# Altium schematic sheet FM-STC15.SchDoc
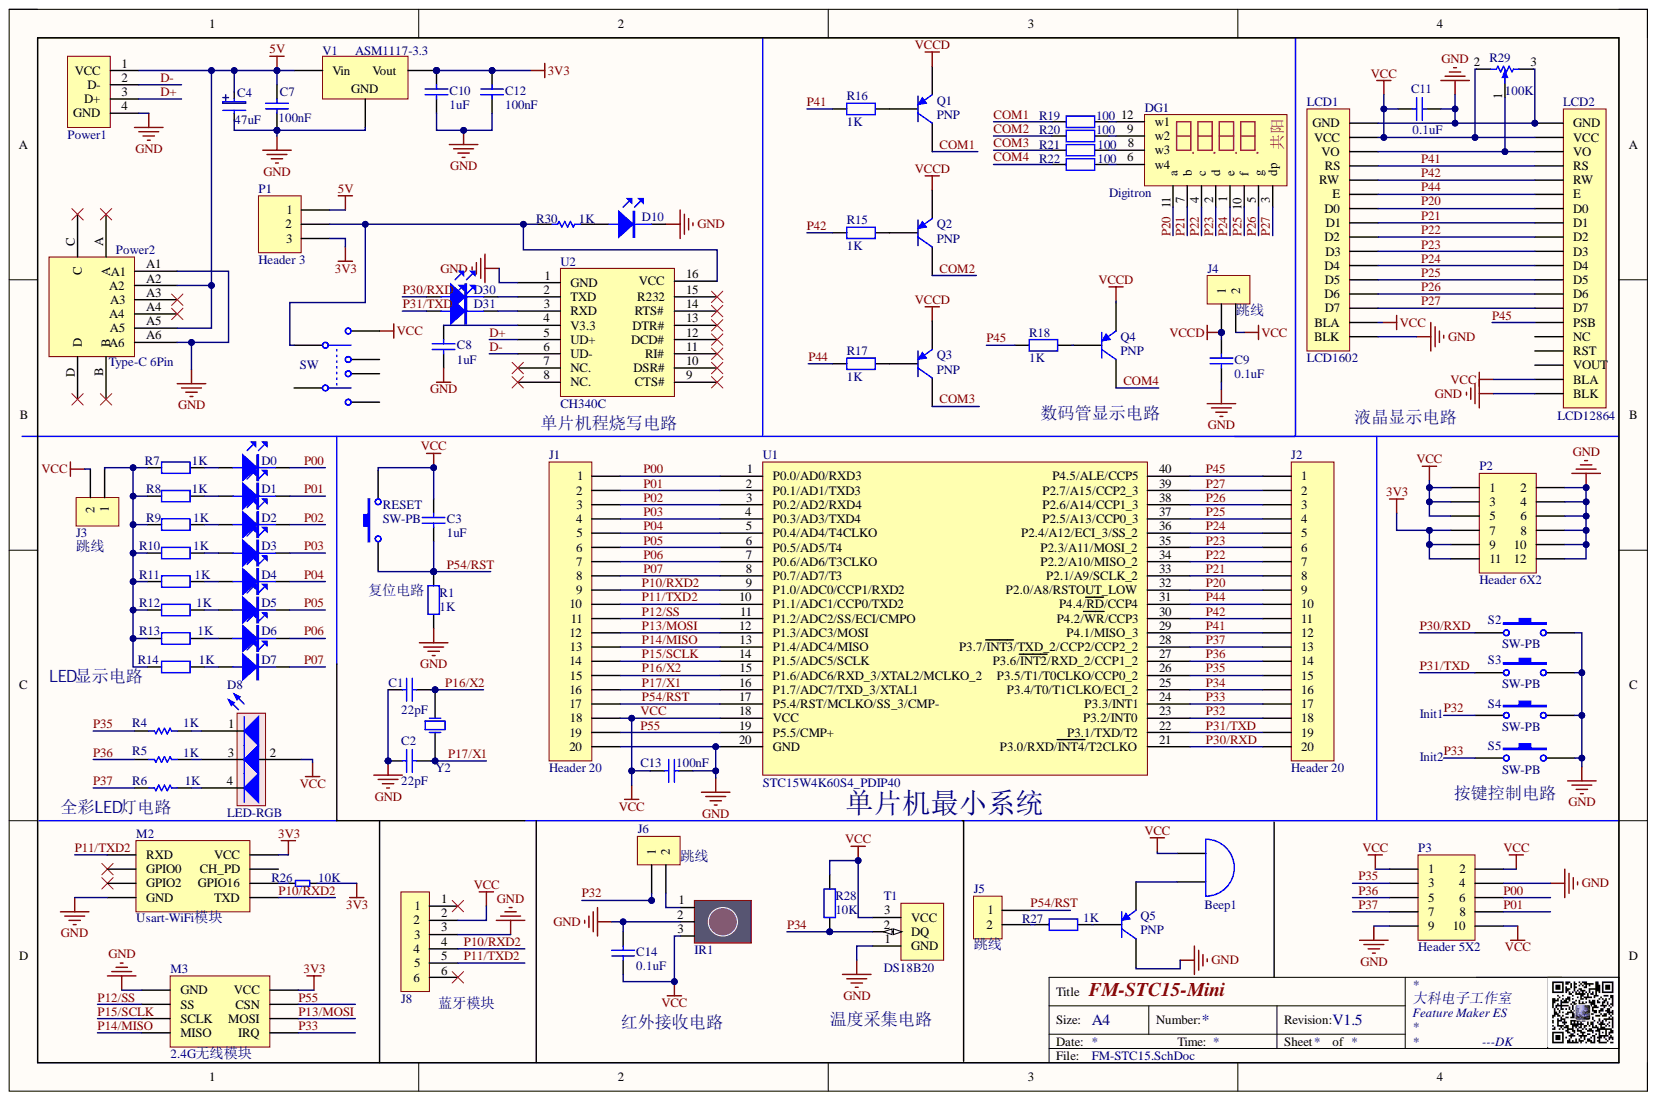
[diagram p0: FM-STC15.SchDoc]

## Parts
Beep1  蜂鸣器  [BELL]  (value not drawn)
C1  value 22pF  [0805-3]  (hidden comment: Cap_SMT)
C2  value 22pF  [0805-3]  (hidden comment: Cap_SMT)
C3  value 1uF  [0805-3]  (hidden comment: Cap_SMT)
C4  47uF  [SMD6.6*6.5]
C7  value 100nF  [0805-3]  (hidden comment: Cap_SMT)
C8  value 1uF  [0805-3]  (hidden comment: Cap)
C9  value 0.1uF  [0805-3]  (hidden comment: Cap_SMT)
C10  value 1uF  [0805-3]  (hidden comment: 100nf)
C11  value 0.1uF  [0805-3]  (hidden comment: Cap_SMT)
C12  value 100nF  [0805-3]  (hidden comment: Cap_SMT)
C13  value 100nF  [0805-3]  (hidden comment: Cap_SMT)
C14  value 0.1uF  [0805-3]  (hidden comment: Cap_SMT)
D0  LED0805  [0805-LED]  (value not drawn)
D1  LED0805  [0805-LED]  (value not drawn)
D2  LED0805  [0805-LED]  (value not drawn)
D3  LED0805  [0805-LED]  (value not drawn)
D4  LED0805  [0805-LED]  (value not drawn)
D5  LED0805  [0805-LED]  (value not drawn)
D6  LED0805  [0805-LED]  (value not drawn)
D7  LED0805  [0805-LED]  (value not drawn)
D8  LED-RGB  [LED-RGB]
D10  LED0805  [0805-LED]  (value not drawn)
D30  LED0805  [0805-3]  (value not drawn)
D31  LED0805  [0805-3]  (value not drawn)
DG1  Digitron  [DYP-4BIT]
IR1  红外接收头  [HDR1X3]  (value not drawn)
J1  Header 20  [HDR1X20-]
J2  Header 20  [HDR1X20-]
J3  跳线  [HDR1X2]
J4  跳线  [HDR1X2]
J5  跳线  [HDR1X2]
J6  跳线  [HDR1X2]
J8  蓝牙模块  [HDR1X6]
LCD1  LCD1602  [HDR1X16]
LCD2  LCD12864  [HDR1X20]
M2  Usart-WiFi模块  [HDR2X4-]
M3  2.4G无线模块  [HDR2X4-]
P1  Header 3  [HDR1X3]
P2  Header 6X2  [HDR2X6-]
P3  Header 5X2  [HDR5X2-]
Power1  USB-B  [USB_1]  (value not drawn)
Power2  Type-C 6Pin  [Type-C_ 6Pin]
Q1  PNP  [SOT-23_M]
Q2  PNP  [SOT-23_M]
Q3  PNP  [SOT-23_M]
Q4  PNP  [SOT-23_M]
Q5  PNP  [SOT-23_M]
R1  value 1K  [0805-3]  (hidden comment: Res_SMT)
R4  1K  [0805-3]
R5  1K  [0805-3]
R6  1K  [0805-3]
R7  value 1K  [0805-3]  (hidden comment: Res_SMT)
R8  value 1K  [0805-3]  (hidden comment: Res_SMT)
R9  value 1K  [0805-3]  (hidden comment: Res_SMT)
R10  value 1K  [0805-3]  (hidden comment: Res_SMT)
R11  value 1K  [0805-3]  (hidden comment: Res_SMT)
R12  value 1K  [0805-3]  (hidden comment: Res_SMT)
R13  value 1K  [0805-3]  (hidden comment: Res_SMT)
R14  value 1K  [0805-3]  (hidden comment: Res_SMT)
R15  value 1K  [0805-3]  (hidden comment: Res_SMT)
R16  value 1K  [0805-3]  (hidden comment: Res_SMT)
R17  value 1K  [0805-3]  (hidden comment: Res_SMT)
R18  value 1K  [0805-3]  (hidden comment: Res_SMT)
R19  value 100  [0805-3]  (hidden comment: Res_SMT)
R20  value 100  [0805-3]  (hidden comment: Res_SMT)
R21  value 100  [0805-3]  (hidden comment: Res_SMT)
R22  value 100  [0805-3]  (hidden comment: Res_SMT)
R26  value 10K  [0805-3]  (hidden comment: R0805)
R27  value 1K  [0805-3]  (hidden comment: Res_SMT)
R28  value 10K  [0805-3]  (hidden comment: Res_SMT)
R29  100K  [Rel]
R30  value 1K  [0805-3]  (hidden comment: Res1)
RESET  SW-PB  [KeyA]
S2  SW-PB  [KEY-SMD]
S3  SW-PB  [KEY-SMD]
S4  SW-PB  [KEY-SMD]
S5  SW-PB  [KEY-SMD]
SW  SW-DPDT  [DPDT-6]  (value not drawn)
T1  DS18B20  [HDR1X3]
U1  STC15W4K60S4_PDIP40  [DIP40]
U2  CH340C  [SO-16]
V1  ASM1117-3.3  [SOT223_N]
Y2  11.0592  [HDR1X3]  (value not drawn)

## Nets
3V3: C10.2 C12.2 M2.2 M3.2 P1.3 P2.7 P2.9 P2.11 R26.1 V1.3
5V: C4.1 C7.1 P1.1 Power1.1 Power2.A2 Power2.A5 V1.1
GND: C1.2 C2.2 C4.2 C7.2 C8.2 C9.1 C10.1 C11.2 C12.1 C13.2 C14.2 D10.2 IR1.2 J1.20 J8.3 LCD1.1 LCD1.16 LCD2.1 LCD2.20 M2.7 M3.1 P2.2 P2.4 P2.6 P2.8 P2.10 P2.12 P3.4 P3.9 Power1.4 Power2.A1 Power2.A6 Q5.3 R1.1 R29.3 S2.2 S3.2 S4.2 S5.2 T1.1 U1.20 U2.1 V1.2
VCCD: C9.2 J4.1 Q1.2 Q2.2 Q3.2 Q4.2
COM1: Q1.3 R19.1
COM2: Q2.3 R20.1
COM3: Q3.3 R21.1
COM4: Q4.3 R22.1
D+: Power1.3 U2.5
D-: Power1.2 U2.6
P00: D0.2 J1.1 P3.6 U1.1
P01: D1.2 J1.2 P3.8 U1.2
P02: D2.2 J1.3 U1.3
P03: D3.2 J1.4 U1.4
P04: D4.2 J1.5 U1.5
P05: D5.2 J1.6 U1.6
P06: D6.2 J1.7 U1.7
P07: D7.2 J1.8 U1.8
P10/RXD2: J1.9 J8.4 M2.8 U1.9
P11/TXD2: J1.10 J8.5 M2.1 U1.10
P12/SS: J1.11 M3.3 U1.11
P13/MOSI: J1.12 M3.6 U1.12
P14/MISO: J1.13 M3.7 U1.13
P15/SCLK: J1.14 M3.5 U1.14
P16/X2: C1.1 J1.15 U1.15 Y2.1
P17/X1: C2.1 J1.16 U1.16 Y2.3
P20: DG1.11 J2.9 LCD1.7 LCD2.7 U1.32
P21: DG1.7 J2.8 LCD1.8 LCD2.8 U1.33
P22: DG1.4 J2.7 LCD1.9 LCD2.9 U1.34
P23: DG1.2 J2.6 LCD1.10 LCD2.10 U1.35
P24: DG1.1 J2.5 LCD1.11 LCD2.11 U1.36
P25: DG1.10 J2.4 LCD1.12 LCD2.12 U1.37
P26: DG1.5 J2.3 LCD1.13 LCD2.13 U1.38
P27: DG1.3 J2.2 LCD1.14 LCD2.14 U1.39
P30/RXD: D30.1 J2.20 S2.1 U1.21
P31/TXD: D31.1 J2.19 S3.1 U1.22
P32: J2.18 J6.1 S4.1 U1.23
P33: J2.17 M3.8 S5.1 U1.24
P34: J2.16 R28.1 T1.2 U1.25
P35: J2.15 P3.3 R4.1 U1.26
P36: J2.14 P3.5 R5.1 U1.27
P37: J2.13 P3.7 R6.1 U1.28
P41: J2.12 LCD1.4 LCD2.4 R16.2 U1.29
P42: J2.11 LCD1.5 LCD2.5 R15.2 U1.30
P44: J2.10 LCD1.6 LCD2.6 R17.2 U1.31
P45: J2.1 LCD2.15 R18.2 U1.40
P54/RST: C3.2 J1.17 J5.1 R1.2 RESET.2 U1.17
P55: J1.19 M3.4 U1.19
VCC: Beep1.1 C3.1 C11.1 C13.1 C14.1 D8.2 IR1.3 J1.18 J3.2 J4.2 J8.2 LCD1.2 LCD1.15 LCD2.2 LCD2.19 P2.1 P2.3 P2.5 P3.1 P3.2 P3.10 R28.2 R29.2 RESET.1 SW.2 T1.3 U1.18
NetBeep1_2: Beep1.2 Q5.2
NetC8_1: C8.1 U2.4
NetD0_1: D0.1 R7.2
NetD1_1: D1.1 R8.2
NetD2_1: D2.1 R9.2
NetD3_1: D3.1 R10.2
NetD4_1: D4.1 R11.2
NetD5_1: D5.1 R12.2
NetD6_1: D6.1 R13.2
NetD7_1: D7.1 R14.2
NetD8_1: D8.1 R4.2
NetD8_3: D8.3 R5.2
NetD8_4: D8.4 R6.2
NetD10_1: D10.1 R30.2
NetD30_2: D30.2 U2.2
NetD31_2: D31.2 U2.3
NetDG1_6: DG1.6 R22.2
NetDG1_8: DG1.8 R21.2
NetDG1_9: DG1.9 R20.2
NetDG1_12: DG1.12 R19.2
NetIR1_1: IR1.1 J6.2
NetJ3_1: J3.1 R7.1 R8.1 R9.1 R10.1 R11.1 R12.1 R13.1 R14.1
NetJ5_2: J5.2 R27.2
NetLCD1_3: LCD1.3 LCD2.3 R29.1
NetM2_6: M2.6 R26.2
NetP1_2: P1.2 R30.1 SW.3 U2.16
NetQ1_1: Q1.1 R16.1
NetQ2_1: Q2.1 R15.1
NetQ3_1: Q3.1 R17.1
NetQ4_1: Q4.1 R18.1
NetQ5_1: Q5.1 R27.1

Not connected: J8 1 6; LCD2 16 17 18; M2 3 4 5; Power2 A A3 A4 B C D; SW 1 4 5 6; U2 7 8 9 10 11 12 13 14 15

## Library data of the parts
C1-C3 C7 C9-C14 = Cap_SMT: Capacitor; Component Kind: Standard; Library Name: My_Library1.IntLib
C4 = 钽电容1206: Value: 10uF; Component Kind: Standard; Description: 47UF; Library Name: 贴片电容.IntLib
C8 = Cap: Capacitor; Component Kind: Standard; LatestRevisionDate: 17-Jul-2002; LatestRevisionNote: Re-released for DXP Platform.; Library Name: Miscellaneous Devices.IntLib; PackageDescription: Radial Cap, Thru-Hole; 2 Leads; 0.3 in Pin Spacing; PackageReference: RAD-0.3; Published: 8-Jun-2000; Signal Integrity: Cap; Simulation: CAP; Publisher: Altium Limited
D8 = LED-RGB: 三基色LED; Component Kind: Standard; LatestRevisionDate: 08-Jul-2005; LatestRevisionNote: Mech Layer 1 removed.; PackageReference: LED-0; Published: 8-Jun-2000; Library Name: Fszhang.IntLib; Publisher: Altium Limited
J1 J2 = Header 20: Header, 20-Pin; Component Kind: Standard; LatestRevisionDate: 17-Jul-2002; LatestRevisionNote: Re-released for DXP Platform.; Library Name: Miscellaneous Connectors.IntLib; Signal Integrity: Connector; Publisher: Altium Limited
J3-J6 = SIP2-0.254: Component Kind: Standard; Library Name: 插接件.IntLib
J8 = SIP6-0.254: Component Kind: Standard; Library Name: 插接件.IntLib
LCD1 = Header 16: Header, 16-Pin; Component Kind: Standard; LatestRevisionDate: 17-Jul-2002; LatestRevisionNote: Re-released for DXP Platform.; Library Name: FM-STC15.SCHLIB; Signal Integrity: Connector; Publisher: Altium Limited
M2 = ESP8266: Component Kind: Standard; Library Name: FM-STC15.SCHLIB
M3 = NRF24L01: Component Kind: Standard; Library Name: FM-STC15.SCHLIB
P1 = Header 3: Header, 3-Pin; Component Kind: Standard; LatestRevisionDate: 17-Jul-2002; LatestRevisionNote: Re-released for DXP Platform.; Library Name: Miscellaneous Connectors.IntLib; Signal Integrity: Connector; Publisher: Altium Limited
P2 = Header 6X2: Header, 6-Pin, Dual row; Component Kind: Standard; LatestRevisionDate: 17-Jul-2002; LatestRevisionNote: Re-released for DXP Platform.; Library Name: Miscellaneous Connectors.IntLib; Signal Integrity: Connector; Publisher: Altium Limited
P3 = Header 5X2: Header, 5-Pin, Dual row; Component Kind: Standard; LatestRevisionDate: 17-Jul-2002; LatestRevisionNote: Re-released for DXP Platform.; Library Name: Miscellaneous Connectors.IntLib; Signal Integrity: Connector; Publisher: Altium Limited
R1 R7-R22 R27 R28 = Res_SMT: Resistor; Component Kind: Standard; Library Name: My_Library1.IntLib
R4-R6 R30 = Res1: Resistor; Component Kind: Standard; LatestRevisionDate: 17-Jul-2002; LatestRevisionNote: Re-released for DXP Platform.; Library Name: Miscellaneous Devices.IntLib; PackageDescription: Axial Device, Thru-Hole; 2 Leads; 0.3 in Pin Spacing; PackageReference: AXIAL-0.3; Published: 8-Jun-2000; Publisher: Altium Limited
  R30: Signal Integrity: Res; Simulation: RESISTOR
R29 = 3362R: Component Kind: Standard; Library Name: 特殊电阻.IntLib
RESET S2-S5 = SW-PB: Switch; Component Kind: Standard; LatestRevisionDate: 17-Jul-2002; LatestRevisionNote: Re-released for DXP Platform.; Library Name: Miscellaneous Devices.IntLib; PackageDescription: Switch; 2 Leads; PackageReference: SPST-2; Published: 24-Mar-1999; Publisher: Altium Limited
SW = SW-DPDT: Double-Pole, Double-Throw Switch; Component Kind: Standard; LatestRevisionDate: 17-Jul-2002; LatestRevisionNote: Re-released for DXP Platform.; Library Name: Miscellaneous Devices.IntLib; PackageDescription: Switch; 6 Leads; PackageReference: DPDT-6; Published: 24-Mar-1999; Publisher: Altium Limited
U2 = CH340C: Chip ,SOP16; Component Kind: Standard; Library Name: FM-STC15.SCHLIB
V1 = Volt Reg: Voltage Regulator; Component Kind: Standard; LatestRevisionDate: 29-May-2009; LatestRevisionNote: IPC-7351 Footprint Added.; Library Name: Miscellaneous Devices.IntLib; PackageDescription: 3-Pin Transistor Outline Package 2.54 mm Pitch, 15.1 mm Lead Span; PackageReference: D2PAK; Published: 24-Mar-1999; Publisher: Altium Limited
Y2 = XTAL: Component Kind: Standard; Library Name: 晶振.SchLib
Beep1 = 蜂鸣器: Component Kind: Standard; Library Name: 声器件.SchLib
D0-D7 D10 D30 D31 = LED0805: Component Kind: Standard; Library Name: 光电器件.IntLib
DG1 = Digitron: Component Kind: Standard; Library Name: My_Library1.IntLib
IR1 = 红外接收头: Component Kind: Standard; Library Name: 传感器.IntLib
LCD2 = LCD12864: Component Kind: Standard; Library Name: FM-STC15.SCHLIB
Power1 = USB-B: Component Kind: Standard; Library Name: 插接件.IntLib
Power2 = Type-C 6Pin: Component Kind: Standard; Library Name: Type-C 6Pin.SchLib
Q1-Q5 = PNP: Component Kind: Standard; Library Name: 贴片晶体管.SchLib
R26 = R0805: Component Kind: Standard; Library Name: 贴片电阻.SchLib
T1 = DS18B20: Component Kind: Standard; Library Name: My_Library1.IntLib
U1 = STC15W4K60S4_PDIP40: Component Kind: Standard; Library Name: stcmcu_altium142.SchLib
